# Altium schematic sheet 04_I2C.SchDoc
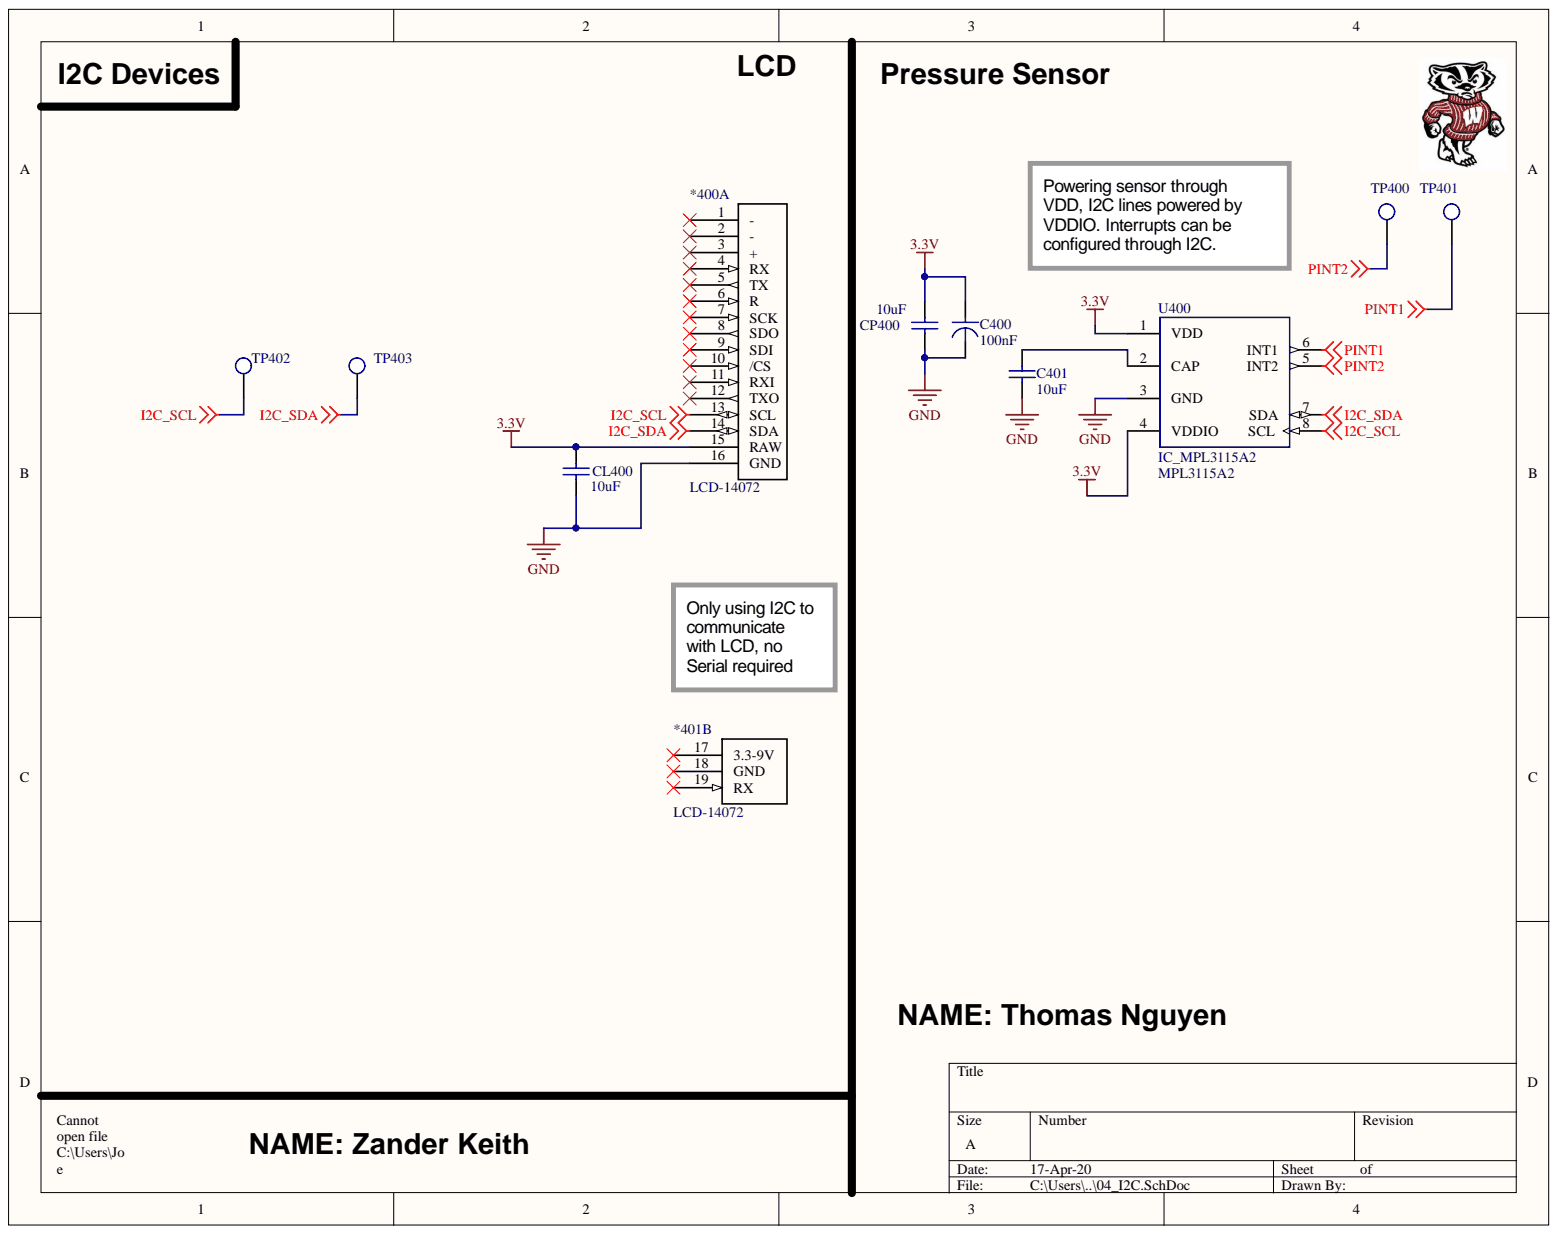
[diagram p0: 04_I2C.SchDoc]

## Parts
*400  LCD_14072  (part A)
*401  (no value)  (part B)
C400  Capacitance: 100nF  (hidden comment: CAP_CAN_N_100.00)
C401  10uF  [CAP_0805]
CL400  10uF  [CAP_0805]
CP400  10uF  [CAP_0805]
TP400  TP_1206  [TP-SMC-1206]  (value not drawn)
TP401  TP_1206  [TP-SMC-1206]  (value not drawn)
TP402  TP_1206  [TP-SMC-1206]  (value not drawn)
TP403  TP_1206  [TP-SMC-1206]  (value not drawn)
U400  IC_MPL3115A2  Manufacturer Part Number: MPL3115A2  [FRES-2153-01_V]

## Nets
3.3V: *400.15(RAW) C400.1 CL400.2 CP400.2 U400.1(VDD) U400.4(VDDIO)
GND: *400.16(GND) C400.2 C401.1 CL400.1 CP400.1 U400.3(GND)
I2C_SCL: *400.13(SCL) TP402.1 U400.8(SCL)
I2C_SDA: *400.14(SDA) TP403.1 U400.7(SDA)
PINT1: TP401.1 U400.6(INT1)
PINT2: TP400.1 U400.5(INT2)
NetC401_2: C401.2 U400.2(CAP)

Not connected: *400 1(-) 2(-) 3(+) 4(RX) 5(TX) 6(R) 7(SCK) 8(SDO) 9(SDI) 10(/CS) 11(RXI) 12(TXO); *401 17(3.3-9V) 18(GND) 19(RX)

## Library data of the parts
*400 *401 = LCD_14072: 16x2 Black on RGB Display https://media.digikey.com/pdf/Data%20Sheets/Sparkfun%20PDFs/LCD-14072_Web.pdf; Component Kind: Standard; Library Name: ece315-S20.SCHLIB
C400 = CAP_CAN_N_100.00: WURTH ELEKTRONIK         865230640001             SMD Aluminium Electrolytic Capacitor, Radial Can - SMD, 0.1 F, 50 V, WCAP-AS5H Series; Manufacturer: Wurth Electronics; Life (Hours): 5000Hour; Polarity: Polar; Ripple Current: 1mA; Tolerance: 20%; Voltage Rating: 50V; Case/Package: Radial; Category: Aluminum Electrolytic Capacitors; Component Kind: Standard; ComponentLink1Description: http://www.farnell.com/datasheets/1880284.pdf?src-supplier=Farnell; ComponentLink1URL: http://www.farnell.com/datasheets/1880284.pdf?src-supplier=Farnell; ComponentLink2Description: https://katalog.we-online.de/pbs/datasheet/865230640001.pdf?src-supplier=Digi-Key; ComponentLink2URL: https://katalog.we-online.de/pbs/datasheet/865230640001.pdf?src-supplier=Digi-Key; ComponentLink3Description: http://katalog.we-online.de/pbs/datasheet/865230640001.pdf?src-supplier=Digi-Key; ComponentLink3URL: http://katalog.we-online.de/pbs/datasheet/865230640001.pdf?src-supplier=Digi-Key; ComponentLink4Description: http://www.farnell.com/datasheets/1880284.pdf?src-supplier=Element14; ComponentLink4URL: http://www.farnell.com/datasheets/1880284.pdf?src-supplier=Element14; ComponentLink5Description: http://www.farnell.com/datasheets/1880284.pdf?src-supplier=Newark; ComponentLink5URL: http://www.farnell.com/datasheets/1880284.pdf?src-supplier=Newark; Diameter: 4mm; Height: 5.5mm; Height - Seated (Max): 0.217inch; Library Name: ece315-S20.SCHLIB; Manufacturer Part Number: 865230640001; Max Operating Temperature: 105°C; Min Operating Temperature: -40°C; Mount: Surface Mount; Package Quantity: 2000; Packaging: Cut Tape; Pricing 1: 1=0.17000, 50=0.14600, 100=0.12700, 250=0.11300, 500=0.09900, 1000=0.09400 (USD); REACH SVHC: Unknown; Ripple Current (AC): 1mA; RoHS: Yes; Stock 1: 20145; Supplier 1: Digi-Key; Supplier Part Number 1: 732-8266-1-ND; Voltage Rating (DC): 50V
C401 CL400 CP400 = CAP_0805_U_010.00: CAP CER 10UF 16V X7R 0805; Manufacturer: Samsung; Manufacturer Part Number: CL21A106KPCLQNC; Dielectric: X5R; Tolerance: 10%; Voltage Rating: 10V; Capacitance: 10uF; Case/Package: 0805; Case Code (Imperial): 0805; Case Code (Metric): 2012; Component Kind: Standard; ComponentLink1Description: https://datasheet.ciiva.com/25730/cl21a106kpclqnc-25730594.pdf; ComponentLink1URL: https://datasheet.ciiva.com/25730/cl21a106kpclqnc-25730594.pdf; ComponentLink3Description: https://datasheet.ciiva.com/26920/getdatasheetpartid-355170-26920201.pdf; ComponentLink3URL: https://datasheet.ciiva.com/26920/getdatasheetpartid-355170-26920201.pdf; Contact Plating: Tin; Depth: 1.25mm; Height: 0.85mm; Length: 2mm; Library Name: ece315-S20.SCHLIB; Max Operating Temperature: 85°C; Min Operating Temperature: -55°C; Mount: Surface Mount; Package Quantity: 1; Packaging: Tape and Reel; Pricing 1: 1=0.22, 10=0.148, 100=0.0749, 500=0.05122, 1000=0.04531 (USD); Radiation Hardening: No; Stock 1: 40000; Supplier 1: Digi-Key; Supplier Part Number 1: 1276-2402-1-ND; Thickness: 0.037inch; Width: 0.049inch
TP400-TP403 = TP_1206: Test Point SMD 1206; Manufacturer: TE Connectivity (Tyco Electronics); Manufacturer Part Number: 1625854-1; Power Rating: N/R; Resistance Value: 0 Ohm; Case Size: 1206; Component Kind: Standard; ComponentLink1Description: https://datasheet.ciiva.com/6439/eng-ds-1773266-a-6439169.pdf; ComponentLink1URL: https://datasheet.ciiva.com/6439/eng-ds-1773266-a-6439169.pdf; ComponentLink2Description: https://datasheet.ciiva.com/30136/1773266-a-30136341.pdf; ComponentLink2URL: https://datasheet.ciiva.com/30136/1773266-a-30136341.pdf; ComponentLink3Description: https://datasheet.ciiva.com/6439/eng-ds-1773266-a-6439080.pdf; ComponentLink3URL: https://datasheet.ciiva.com/6439/eng-ds-1773266-a-6439080.pdf; ComponentLink4Description: https://datasheet.ciiva.com/6439/eng-ds-1773266-a-6439081.pdf; ComponentLink4URL: https://datasheet.ciiva.com/6439/eng-ds-1773266-a-6439081.pdf; ComponentLink5Description: https://datasheet.ciiva.com/6439/eng-ds-1773266-a-6439083.pdf; ComponentLink5URL: https://datasheet.ciiva.com/6439/eng-ds-1773266-a-6439083.pdf; Dimension: 3.2 x 1.6 x 1.25mm; Family: RC; Library Name: ece315-S20.SCHLIB; Mounting: Surface Mount; Operating Temperature: -55 to 125 C; Packaging: Tape and Reel; Part No: 1625854-1; Pricing 1: 1=0.28, 10=0.261, 25=0.224, 50=0.1902, 100=0.1828, 250=0.1641, 500=0.1567, 1000=0.1306 (USD); RoHS: Yes; Stock 1: 31937; Supplier 1: Digi-Key; Supplier Part Number 1: A106143CT-ND; Temperature Coefficient: N/R; Terminal Pitch: N/R; Termination Style: N/R; Tolerance: N/R
U400 = IC_MPL3115A2: Pressure Sensor 20kPa to 110kPa Absolute 8-Pin LGA Tray; Manufacturer: NXP Freescale; Accuracy: 1%; Max Output Voltage: 1V; Max Pressure: 72.52PSI; Max Supply Voltage: 3.6V; Min Output Voltage: 900mV; Min Supply Voltage: 1.95V; Nominal Supply Current: 2uA; Operating Pressure: 15.95PSI; Operating Supply Voltage: 2.5V; Output Type: Digital,I2C; Port Style: No Port; Case/Package: LGA; Category: Pressure Sensors; Component Kind: Standard; ComponentLink1Description: https://datasheet.ciiva.com/5298/mpl3115a2-39416-5298160.pdf?src-supplier=Mouser; ComponentLink1URL: https://datasheet.ciiva.com/5298/mpl3115a2-39416-5298160.pdf?src-supplier=Mouser; ComponentLink2Description: https://datasheet.ciiva.com/14666/0900766b8109ab24-14666722.pdf?src-supplier=RSComponents; ComponentLink2URL: https://datasheet.ciiva.com/14666/0900766b8109ab24-14666722.pdf?src-supplier=RSComponents; ComponentLink3Description: https://datasheet.ciiva.com/1650/1506456-1650883.pdf?src-supplier=Newark; ComponentLink3URL: https://datasheet.ciiva.com/1650/1506456-1650883.pdf?src-supplier=Newark; ComponentLink4Description: https://datasheet.ciiva.com/3272/mpl3115a2-3272740.pdf?src-supplier=Avnet; ComponentLink4URL: https://datasheet.ciiva.com/3272/mpl3115a2-3272740.pdf?src-supplier=Avnet; ComponentLink5Description: https://datasheet.ciiva.com/15222/getdatasheetpartid-567123-15222302.pdf?src-supplier=Verical; ComponentLink5URL: https://datasheet.ciiva.com/15222/getdatasheetpartid-567123-15222302.pdf?src-supplier=Verical; ComponentLink6Description: https://datasheet.ciiva.com/16463/mpl3115a2-16463821.pdf?src-supplier=Future+Electronics; ComponentLink6URL: https://datasheet.ciiva.com/16463/mpl3115a2-16463821.pdf?src-supplier=Future+Electronics; ComponentLink7Description: https://datasheet.ciiva.com/2843/mpl3115a2-2843362.pdf?src-supplier=Digi-Key; ComponentLink7URL: https://datasheet.ciiva.com/2843/mpl3115a2-2843362.pdf?src-supplier=Digi-Key; ComponentLink8Description: https://datasheet.ciiva.com/1513/1789334-1513798.pdf?src-supplier=Element14; ComponentLink8URL: https://datasheet.ciiva.com/1513/1789334-1513798.pdf?src-supplier=Element14; ComponentLink9Description: https://datasheet.ciiva.com/26023/mpl3115a2-26023222.pdf?src-supplier=Avnet+Europe; ComponentLink9URL: https://datasheet.ciiva.com/26023/mpl3115a2-26023222.pdf?src-supplier=Avnet+Europe; Contact Plating: Gold; Height: 1.2mm; Length: 5mm; Library Name: ece315-S20.SCHLIB; Max Operating Temperature: 85°C; Min Operating Temperature: -40°C; Number of Pins: 8; Package Quantity: 490; Packaging: Tray; Pressure Type: Absolute; Pricing 1: 1=5.80000, 5=5.12000, 10=4.52300, 25=3.67000, 50=3.24320, 100=3.15780, 500=2.64570, 1000=2.47501, 5000=2.30432 (USD); Radiation Hardening: No; REACH SVHC: No SVHC; RoHS: Yes; Stock 1: 7701; Supplier 1: Digi-Key; Supplier Part Number 1: MPL3115A2-ND; Termination: PCB; Weight: 0.001104oz; Width: 3mm
